# Altium schematic sheet FPGA_Power_Bank.SchDoc(U_FPGA_Power_Bank)
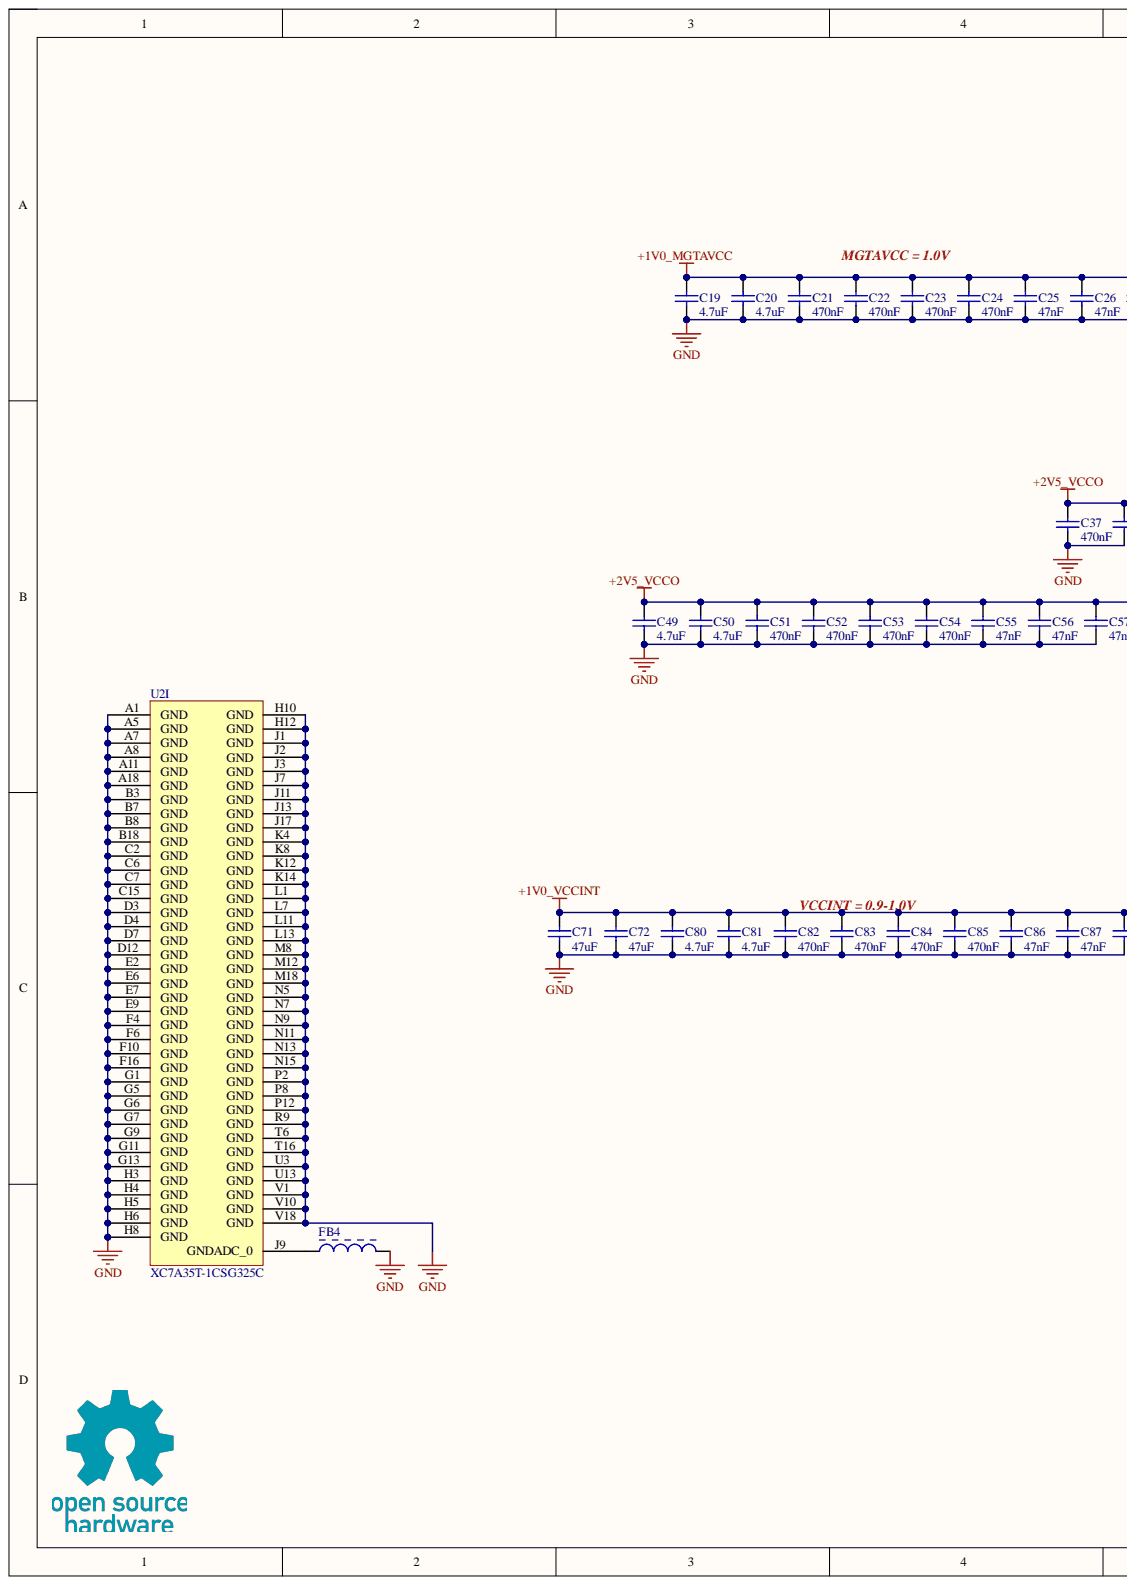
[diagram p0: FPGA_Power_Bank.SchDoc, left]
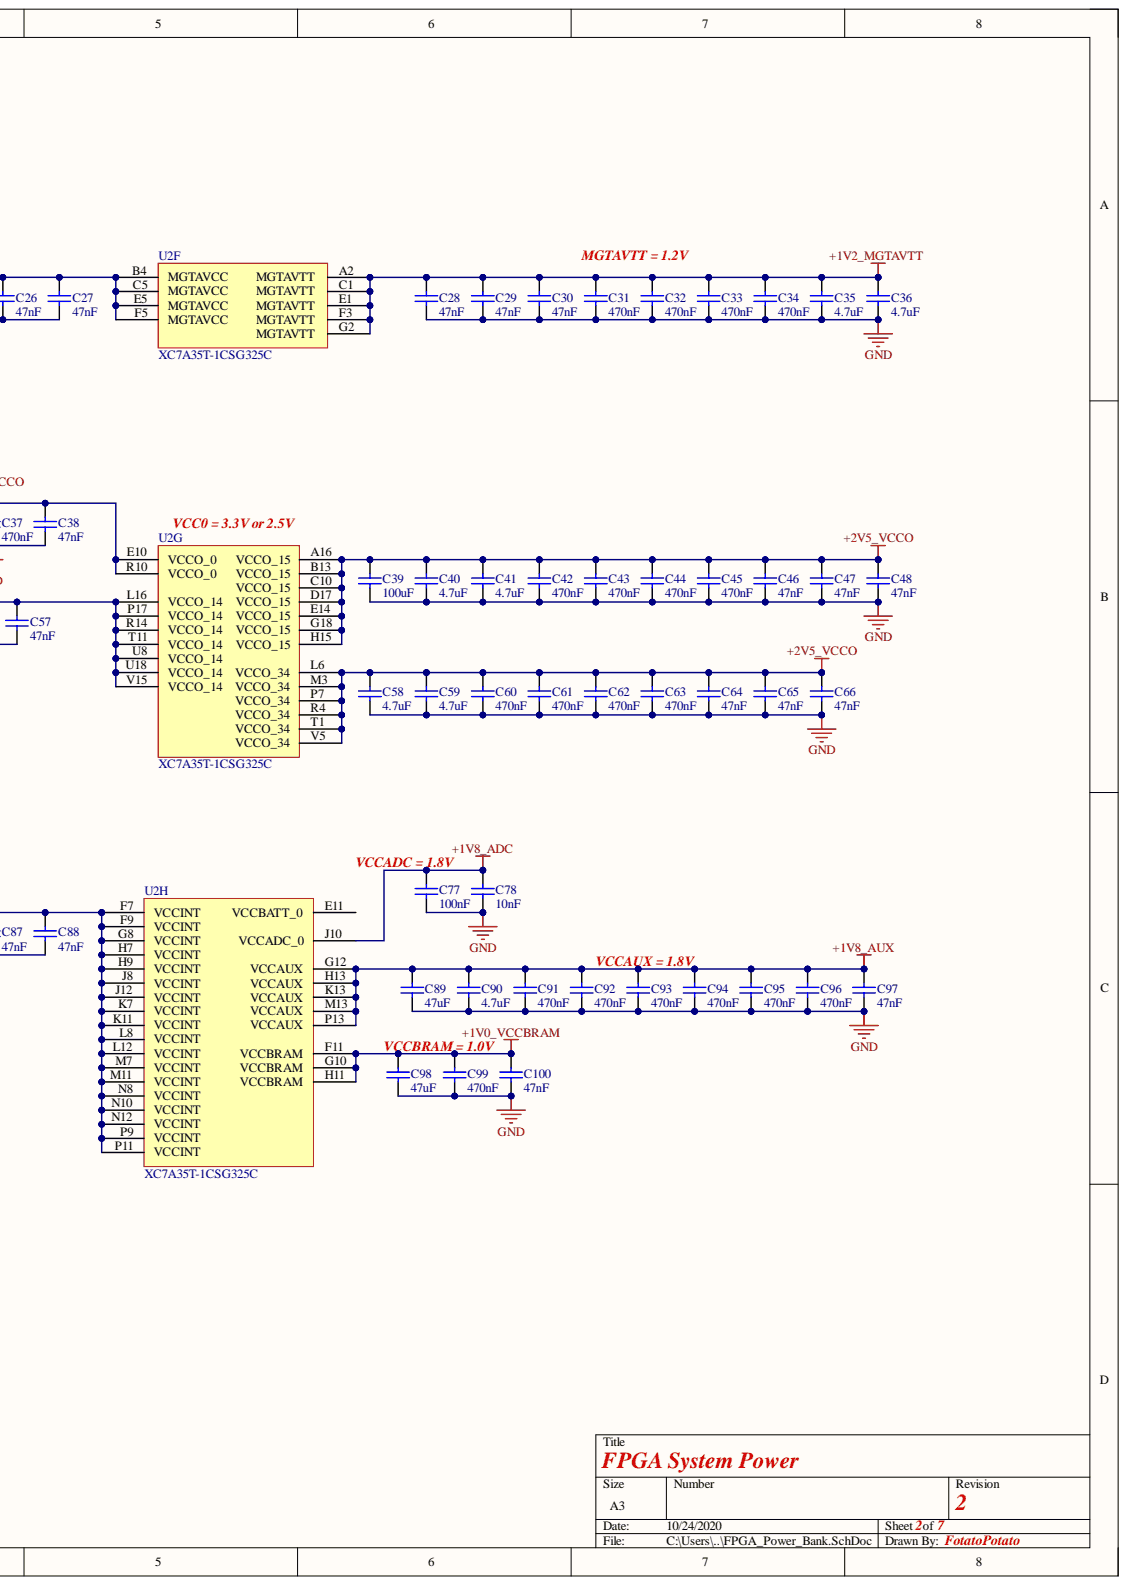
[diagram p1: FPGA_Power_Bank.SchDoc, right]

## Parts
C19  4.7uF  [CAPC1005X55X25NL05T10]
C20  4.7uF  [CAPC1005X55X25NL05T10]
C21  470nF  [CAPC1005X55X25LL05T10]
C22  470nF  [CAPC1005X55X25LL05T10]
C23  470nF  [CAPC1005X55X25LL05T10]
C24  470nF  [CAPC1005X55X25LL05T10]
C25  47nF  [CAPC1005X60X30ML05T10]
C26  47nF  [CAPC1005X60X30ML05T10]
C27  47nF  [CAPC1005X60X30ML05T10]
C28  47nF  [CAPC1005X60X30ML05T10]
C29  47nF  [CAPC1005X60X30ML05T10]
C30  47nF  [CAPC1005X60X30ML05T10]
C31  470nF  [CAPC1005X55X25LL05T10]
C32  470nF  [CAPC1005X55X25LL05T10]
C33  470nF  [CAPC1005X55X25LL05T10]
C34  470nF  [CAPC1005X55X25LL05T10]
C35  4.7uF  [CAPC1005X55X25NL05T10]
C36  4.7uF  [CAPC1005X55X25NL05T10]
C37  470nF  [CAPC1005X55X25LL05T10]
C38  47nF  [CAPC1005X60X30LL05T10]
C39  100uF  [CAPC2013X145X45LL20T25]
C40  4.7uF  [CAPC1005X55X25NL05T10]
C41  4.7uF  [CAPC1005X55X25NL05T10]
C42  470nF  [CAPC1005X55X25LL05T10]
C43  470nF  [CAPC1005X55X25LL05T10]
C44  470nF  [CAPC1005X55X25LL05T10]
C45  470nF  [CAPC1005X55X25LL05T10]
C46  47nF  [CAPC1005X60X30ML05T10]
C47  47nF  [CAPC1005X60X30ML05T10]
C48  47nF  [CAPC1005X60X30ML05T10]
C49  4.7uF  [CAPC1005X55X25NL05T10]
C50  4.7uF  [CAPC1005X55X25NL05T10]
C51  470nF  [CAPC1005X55X25LL05T10]
C52  470nF  [CAPC1005X55X25LL05T10]
C53  470nF  [CAPC1005X55X25LL05T10]
C54  470nF  [CAPC1005X55X25LL05T10]
C55  47nF  [CAPC1005X60X30ML05T10]
C56  47nF  [CAPC1005X60X30ML05T10]
C57  47nF  [CAPC1005X60X30ML05T10]
C58  4.7uF  [CAPC1005X55X25NL05T10]
C59  4.7uF  [CAPC1005X55X25NL05T10]
C60  470nF  [CAPC1005X55X25LL05T10]
C61  470nF  [CAPC1005X55X25LL05T10]
C62  470nF  [CAPC1005X55X25LL05T10]
C63  470nF  [CAPC1005X55X25LL05T10]
C64  47nF  [CAPC1005X60X30ML05T10]
C65  47nF  [CAPC1005X60X30ML05T10]
C66  47nF  [CAPC1005X60X30ML05T10]
C71  47uF  [CAPC1608X100X38LL20T18]
C72  47uF  [CAPC1608X100X38LL20T18]
C77  100nF  [CAPC1005X56X25LL10T15]
C78  10nF  [CAPC1005X55X25LL05T10]
C80  4.7uF  [CAPC1005X55X25LL05T10]
C81  4.7uF  [CAPC1005X55X25NL05T10]
C82  470nF  [CAPC1005X55X25LL05T10]
C83  470nF  [CAPC1005X55X25LL05T10]
C84  470nF  [CAPC1005X55X25LL05T10]
C85  470nF  [CAPC1005X55X25LL05T10]
C86  47nF  [CAPC1005X60X30LL05T10]
C87  47nF  [CAPC1005X60X30LL05T10]
C88  47nF  [CAPC1005X60X30LL05T10]
C89  47uF  [CAPC2013X145X45NL20T25]
C90  4.7uF  [CAPC1005X55X25NL05T10]
C91  470nF  [CAPC1005X55X25LL05T10]
C92  470nF  [CAPC1005X55X25LL05T10]
C93  470nF  [CAPC1005X55X25LL05T10]
C94  470nF  [CAPC1005X55X25LL05T10]
C95  470nF  [CAPC1005X55X25LL05T10]
C96  470nF  [CAPC1005X55X25LL05T10]
C97  47nF  [CAPC1005X60X30ML05T10]
C98  47uF  [CAPC2013X145X45NL20T25]
C99  470nF  [CAPC1005X55X25LL05T10]
C100  47nF  [CAPC1005X60X30LL05T10]
FB4  BLM18HD601SN1D  [MURA-BLM18-RF-H4-CHIP-2_V]  (value not drawn)
U2  XC7A35T-1CSG325C  [CSG325]  (parts F,G,H,I)

## Nets
+1V0_MGTAVCC: C19.2 C20.2 C21.2 C22.2 C23.2 C24.2 C25.2 C26.2 C27.2 U2.B4(MGTAVCC) U2.C5(MGTAVCC) U2.E5(MGTAVCC) U2.F5(MGTAVCC)
+1V0_VCCBRAM: C98.2 C99.2 C100.2 U2.F11(VCCBRAM) U2.G10(VCCBRAM) U2.H11(VCCBRAM)
+1V0_VCCINT: C71.2 C72.2 C80.2 C81.2 C82.2 C83.2 C84.2 C85.2 C86.2 C87.2 C88.2 U2.F7(VCCINT) U2.F9(VCCINT) U2.G8(VCCINT) U2.H7(VCCINT) U2.H9(VCCINT) U2.J8(VCCINT) U2.J12(VCCINT) U2.K7(VCCINT) U2.K11(VCCINT) U2.L8(VCCINT) U2.L12(VCCINT) U2.M7(VCCINT) U2.M11(VCCINT) U2.N8(VCCINT) U2.N10(VCCINT) U2.N12(VCCINT) U2.P9(VCCINT) U2.P11(VCCINT)
+1V2_MGTAVTT: C28.2 C29.2 C30.2 C31.2 C32.2 C33.2 C34.2 C35.2 C36.2 U2.A2(MGTAVTT) U2.C1(MGTAVTT) U2.E1(MGTAVTT) U2.F3(MGTAVTT) U2.G2(MGTAVTT)
+1V8_ADC: C77.2 C78.2 U2.J10(VCCADC_0)
+1V8_AUX: C89.2 C90.2 C91.2 C92.2 C93.2 C94.2 C95.2 C96.2 C97.2 U2.G12(VCCAUX) U2.H13(VCCAUX) U2.K13(VCCAUX) U2.M13(VCCAUX) U2.P13(VCCAUX)
+2V5_VCCO: C37.2 C38.2 C39.2 C40.2 C41.2 C42.2 C43.2 C44.2 C45.2 C46.2 C47.2 C48.2 C49.2 C50.2 C51.2 C52.2 C53.2 C54.2 C55.2 C56.2 C57.2 C58.2 C59.2 C60.2 C61.2 C62.2 C63.2 C64.2 C65.2 C66.2 U2.A16(VCCO_15) U2.B13(VCCO_15) U2.C10(VCCO_15) U2.D17(VCCO_15) U2.E10(VCCO_0) U2.E14(VCCO_15) U2.G18(VCCO_15) U2.H15(VCCO_15) U2.L6(VCCO_34) U2.L16(VCCO_14) U2.M3(VCCO_34) U2.P7(VCCO_34) U2.P17(VCCO_14) U2.R4(VCCO_34) U2.R10(VCCO_0) U2.R14(VCCO_14) U2.T1(VCCO_34) U2.T11(VCCO_14) U2.U8(VCCO_14) U2.U18(VCCO_14) U2.V5(VCCO_34) U2.V15(VCCO_14)
GND: C19.1 C20.1 C21.1 C22.1 C23.1 C24.1 C25.1 C26.1 C27.1 C28.1 C29.1 C30.1 C31.1 C32.1 C33.1 C34.1 C35.1 C36.1 C37.1 C38.1 C39.1 C40.1 C41.1 C42.1 C43.1 C44.1 C45.1 C46.1 C47.1 C48.1 C49.1 C50.1 C51.1 C52.1 C53.1 C54.1 C55.1 C56.1 C57.1 C58.1 C59.1 C60.1 C61.1 C62.1 C63.1 C64.1 C65.1 C66.1 C71.1 C72.1 C77.1 C78.1 C80.1 C81.1 C82.1 C83.1 C84.1 C85.1 C86.1 C87.1 C88.1 C89.1 C90.1 C91.1 C92.1 C93.1 C94.1 C95.1 C96.1 C97.1 C98.1 C99.1 C100.1 FB4.2 U2.A1(GND) U2.A5(GND) U2.A7(GND) U2.A8(GND) U2.A11(GND) U2.A18(GND) U2.B3(GND) U2.B7(GND) U2.B8(GND) U2.B18(GND) U2.C2(GND) U2.C6(GND) U2.C7(GND) U2.C15(GND) U2.D3(GND) U2.D4(GND) U2.D7(GND) U2.D12(GND) U2.E2(GND) U2.E6(GND) U2.E7(GND) U2.E9(GND) U2.F4(GND) U2.F6(GND) U2.F10(GND) U2.F16(GND) U2.G1(GND) U2.G5(GND) U2.G6(GND) U2.G7(GND) U2.G9(GND) U2.G11(GND) U2.G13(GND) U2.H3(GND) U2.H4(GND) U2.H5(GND) U2.H6(GND) U2.H8(GND) U2.H10(GND) U2.H12(GND) U2.J1(GND) U2.J2(GND) U2.J3(GND) U2.J7(GND) U2.J11(GND) U2.J13(GND) U2.J17(GND) U2.K4(GND) U2.K8(GND) U2.K12(GND) U2.K14(GND) U2.L1(GND) U2.L7(GND) U2.L11(GND) U2.L13(GND) U2.M8(GND) U2.M12(GND) U2.M18(GND) U2.N5(GND) U2.N7(GND) U2.N9(GND) U2.N11(GND) U2.N13(GND) U2.N15(GND) U2.P2(GND) U2.P8(GND) U2.P12(GND) U2.R9(GND) U2.T6(GND) U2.T16(GND) U2.U3(GND) U2.U13(GND) U2.V1(GND) U2.V10(GND) U2.V18(GND)
NetFB4_1: FB4.1 U2.J9(GNDADC_0)

Not connected: U2 E11(VCCBATT_0)

## Library data of the parts
C19 C20 C35 C36 C40 C41 C49 C50 C58 C59 C80 C81 C90 = CMP-2008-04549-1: Manufacturer: Murata; Part Number: GRM155R60J475ME47D; Component Kind: Standard; Library Name: Altium Content Vault
C21-C24 C31-C34 C37 C42-C45 C51-C54 C60-C63 C82-C85 C91-C96 C99 = CMP-2008-04548-1: Manufacturer: Murata; Part Number: GRM155R60J474KE19D; Component Kind: Standard; Library Name: Altium Content Vault
C25-C30 C38 C46-C48 C55-C57 C64-C66 C86-C88 C97 C100 = CMP-2008-02103-1: Manufacturer: Kemet; Part Number: C0402C473K9RACTU; Component Kind: Standard; Library Name: Altium Content Vault
C39 = CMP-2000-07599-1: Chip Capacitor, 100 uF, +/- 20%, 6.3 V, -55 to 85 degC, 0805 (2012 Metric), RoHS, Tape and Reel; Manufacturer: Murata; Dielectric: X5R; Voltage Rating: 6.3V; Capacitance: 100uF; Case Code (Imperial): 0805; Case Code (Metric): 2012; Case/Package: 0805; Component Kind: Standard; Datasheet URL: http://search.murata.co.jp/Ceramy/image/img/A01X/G101/ENG/GRM21BR60J107ME15-01.pdf; Datasheet Version: 03/2016; Lead Free: LeadFree; Length: 0.079inch; Library Name: Altium Content Vault; Manufacturer URL: http://www.murata.com/; Max Operating Temperature: 85degC; Min Operating Temperature: -55degC; Mount: SurfaceMount; Mounting Technology: SurfaceMount; Package Description: 2-Pin Surface Mount Device, Body 2 x 1.25 mm; Package Reference: 805; Packaging: CutTape; REACH SVHC: NoSVHC; RoHSCompliant: True; Thickness: 0.057inch; Tolerance: 20%; Voltage Rating (DC): 6.3V; Width: 0.049inch
C71 C72 = CMP-2000-05444-1: Chip Capacitor, 47 uF, +/- 20%, 6.3 V, -55 to 85 degC, 0603 (1608 Metric), RoHS, Tape and Reel; Manufacturer: MurataElectronicsNorthAmerica; Capacitance: 47µF; Temperature Coefficient: X5R; Voltage - Rated: 6.3V; Applications: GeneralPurpose; Category: Capacitors; Component Kind: Standard; Datasheet URL: http://www.murata.com/~/media/webrenewal/support/library/catalog/products/capacitor/mlcc/c02e.ashx?la=en; Datasheet Version: Aug-14; Family: CeramicCapacitors; Features: DeratingRecommended; Height - Seated (Max): -; Lead Spacing: -; Lead Style: -; Library Name: Altium Content Vault; Manufacturer URL: http://www.murata.com/; Mounting Type: SurfaceMount,MLCC; Operating Temperature: -55degC~85degC; Package / Case: 0603(1608Metric); Package Description: 2-Pin Surface Mount Device, Body 1.6 x 0.8 mm; Package Reference: 1608; Packaging: CutTape(CT); Ratings: -; Series: GRM; Size / Dimension: 0.063"Lx0.031"W(1.60mmx0.80mm); Thickness (Max): 0.039"(1.00mm); Tolerance: ±20%
C77 = CMP-2008-02743-1: Manufacturer: AVX Interconnect / Elco; Part Number: 04026D104KAT2A; Component Kind: Standard; Library Name: Altium Content Vault
C78 = CMP-2008-03953-1: Manufacturer: Murata; Part Number: GRM155R70J103KA01D; Component Kind: Standard; Library Name: Altium Content Vault
C89 C98 = CMP-2007-03221-1: Manufacturer: Murata; Part Number: GRM21BR60J476ME15L; Component Kind: Standard; Library Name: Altium Content Vault
FB4 = CMP-0686-00390-2: Chip Ferrite Bead for GHz Band High-speed Signal Lines, 600 Ohm, 100 mA, -55 to 125 degC, 1.6 x 0.8 x 0.95 mm SMD, Tape and Reel; Manufacturer: Murata; DC Resistance: 1.50 Ohm Max.; Impedance (at 100 MHz): 600 Ohm +/- 25%; Rated Current: 100 mA; Component Kind: Standard; ComponentLink1Description: Manufacturer URL; ComponentLink1URL: http://www.murata.com/; ComponentLink2Description: Datasheet; ComponentLink2URL: http://www.murata.com/products/catalog/pdf/c31e.pdf; ComponentLink3Description: Package Specification; ComponentLink3URL: http://www.murata.com/products/catalog/pdf/c31e.pdf; DatasheetVersion: 08/2013; Library Name: Altium Content Vault; Mounting Technology: Surface Mount; Operating Temperature Range: -55 to 125 degC; PackageDescription: 2-Pin Chip, Body 1.6 x 0.8 mm; PackageReference: BLM18_H950-2; Packing: Tape and Reel; PartNumber: BLM18HD601SN1D
U2 = CMP-0383-00016-1: Artix-7 FPGA, 150 User I/Os, 4 GTP, 324-Ball BGA, Speed Grade 1, Commercial Grade, Pb-Free; Manufacturer: Xilinx; Available User I/O: 150; CMTs: 5; GTP: 4; Logic Cells: 33280; Speed Grades: 1; Total Block RAM: 1800 Kb; Component Kind: Standard; ComponentLink1Description: Manufacturer URL; ComponentLink1URL: http://www.xilinx.com/; ComponentLink2Description: Datasheet; ComponentLink2URL: http://www.xilinx.com/support/documentation/data_sheets/ds180_7Series_Overview.pdf; ComponentLink3Description: Pinout; ComponentLink3URL: http://www.xilinx.com/support/documentation/user_guides/ug475_7Series_Pkg_Pinout.pdf; ComponentLink4Description: Package Specification; ComponentLink4URL: http://www.xilinx.com/support/documentation/user_guides/ug475_7Series_Pkg_Pinout.pdf; DatasheetVersion: Rev. 1.15; Library Name: Altium Content Vault; Maximum Distributed RAM: 400 Kb; Mounting Technology: Surface Mount; Operating Temperature Range: Commercial; PackageDescription: 324-Ball Wire-Bond Chip-Scale BGA, Body 15 x 15 mm, Pitch 0.8 mm; PackageReference: CSG-325; PackageVersion: Rev. 1.11; PartNumber: XC7A35T-1CSG325C
